# Altium schematic sheet Schem, Transadmittance MPU.SchDoc
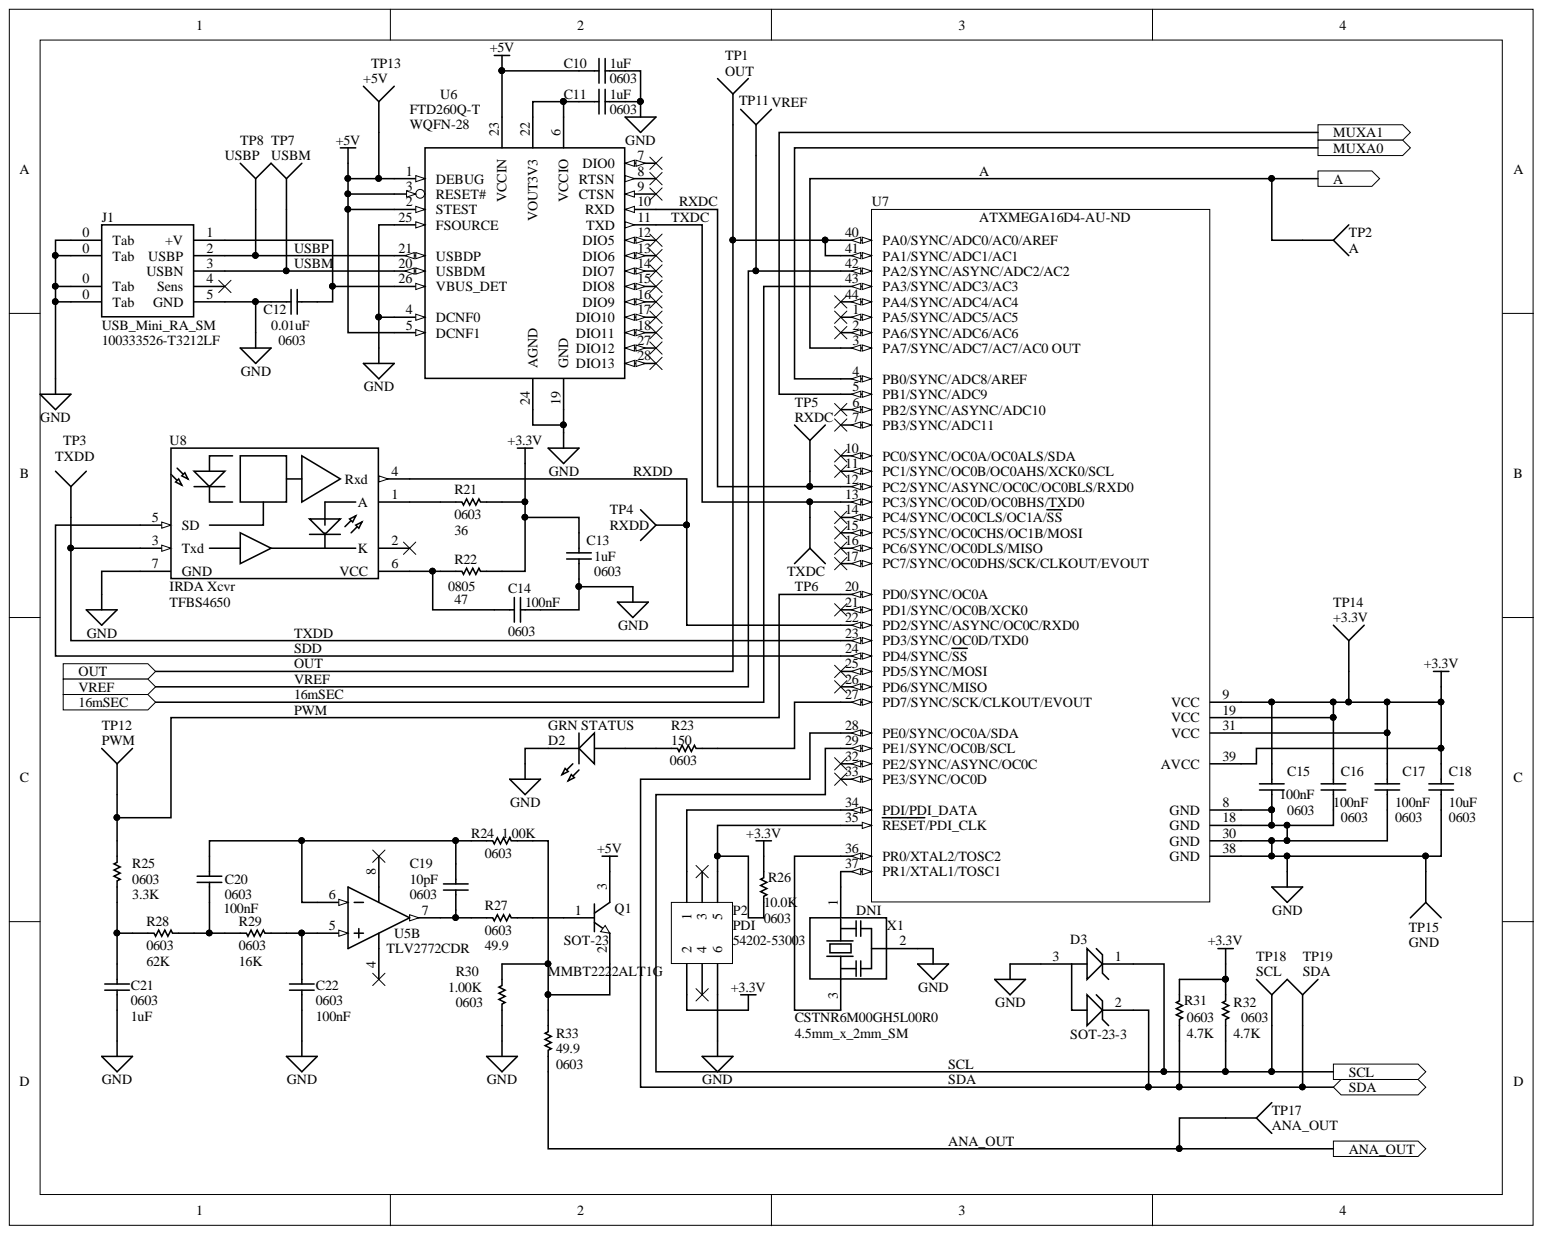
[diagram p0: Schem, Transadmittance MPU.SchDoc]

## Parts
C10  0603  value 1uF  [1608[0603]]
C11  0603  value 1uF  [1608[0603]]
C12  0603  value 0.01uF  [1608[0603]]
C13  0603  value 1uF  [1608[0603]]
C14  0603  value 100nF  [1608[0603]]
C15  0603  value 100nF  [1608[0603]]
C16  0603  value 100nF  [1608[0603]]
C17  0603  value 100nF  [1608[0603]]
C18  0603  value 10uF  [1608[0603]]
C19  0603  value 10pF  [1608[0603]]
C20  0603  value 100nF  [1608[0603]]
C21  0603  value 1uF  [1608[0603]]
C22  0603  value 100nF  [1608[0603]]
D2  GRN STATUS  [3.5X2.8X1.9]
D3  SOT-23-3  [SOT-23_L]
J1  USB_Mini_RA_SM  MFG_P/N: 100333526-T3212LF  [USB-B-Mini_SM_RA]
P2  PDI  MFG_P/N: 54202-53003  [FCI54202]
Q1  SOT-23  MFG_P/N: MMBT2222ALT1G  [SOT-23_N]
R21  0603  value 36  [RESC1608L]
R22  0805  value 47  [RESC1608L]
R23  0603  value 150  [RESC1608L]
R24  0603  value 1.00K  [RESC1608L]
R25  0603  value 3.3K  [RESC1608L]
R26  0603  value 10.0K  [RESC1608L]
R27  0603  value 49.9  [RESC1608L]
R28  0603  value 62K  [RESC1608L]
R29  0603  value 16K  [RESC1608L]
R30  0603  value 1.00K  [RESC1608L]
R31  0603  value 4.7K  [RESC1608L]
R32  0603  value 4.7K  [RESC1608L]
R33  0603  value 49.9  [RESC1608L]
TP1  OUT  [PIN1]
TP2  A  [PIN1]
TP3  TXDD  [PIN1]
TP4  RXDD  [PIN1]
TP5  RXDC  [PIN1]
TP6  TXDC  [PIN1]
TP7  USBM  [PIN1]
TP8  USBP  [PIN1]
TP11  VREF  [PIN1]
TP12  PWM  [PIN1]
TP13  +5V  [PIN1]
TP14  +3.3V  [PIN1]
TP15  GND  [PIN1]
TP17  ANA_OUT  [PIN1]
TP18  SCL  [PIN1]
TP19  SDA  [PIN1]
U5  TLV2772CDR  [D0008A_N]  (part B)
U6  WQFN-28  MFG_P/N: FTD260Q-T  [WQFN-28]
U7  Vendor P/N: ATXMEGA16D4-AU-ND  [44A_N]  (hidden comment: ATxmega16D4-AU)
U8  IRDA Xcvr  [TFBS4650]
X1  4.5mm_x_2mm_SM  value DNI  MFG_P/N: CSTNR6M00GH5L00R0  [CSTNR]

## Ports
16mSEC, A, ANA_OUT, MUXA0, MUXA1, OUT, SCL, SDA, VREF

## Nets
+3.3V: C13.1 C15.1 C16.1 C17.1 C18.1 P2.2 R21.1 R22.1 R26.2 R31.2 R32.2 TP14.1 U7.9(VCC) U7.19(VCC) U7.31(VCC) U7.39(AVCC)
+5V: C10.2 Q1.3 TP13.1 U6.1(DEBUG) U6.2(STEST) U6.3(RESET#) U6.5(DCNF1) U6.23(VCCIN)
GND: C10.1 C11.2 C12.2 C13.2 C14.2 C15.2 C16.2 C17.2 C18.2 C21.2 C22.2 D2.2 D3.3 J1.0(Tab) J1.5(GND) P2.6 R30.1 TP15.1 U6.4(DCNF0) U6.19(GND) U6.24(AGND) U6.25(FSOURCE) U7.8(GND) U7.18(GND) U7.30(GND) U7.38(GND) U8.7(GND) X1.2
16mSEC: U7.43(PA3/SYNC/ADC3/AC3) ; port 16mSEC
A: TP2.1 U7.3(PA7/SYNC/ADC7/AC7/AC0_OUT) ; port A
ANA_OUT: R33.1 TP17.1 ; port ANA_OUT
MUXA0: U7.4(PB0/SYNC/ADC8/AREF) ; port MUXA0
MUXA1: U7.5(PB1/SYNC/ADC9) ; port MUXA1
OUT: TP1.1 U7.40(PA0/SYNC/ADC0/AC0/AREF) U7.41(PA1/SYNC/ADC1/AC1) ; port OUT
PWM: R25.2 TP12.1 U7.20(PD0/SYNC/OC0A)
RXDC: TP5.1 U6.10(RXD) U7.12(PC2/SYNC/ASYNC/OC0C/OC0BLS/RXD0)
RXDD: TP4.1 U7.22(PD2/SYNC/ASYNC/OC0C/RXD0) U8.4(Rxd)
SCL: D3.1 R32.1 TP18.1 U7.29(PE1/SYNC/OC0B/SCL) ; port SCL
SDA: D3.2 R31.1 TP19.1 U7.28(PE0/SYNC/OC0A/SDA) ; port SDA
SDD: U7.24(PD4/SYNC/SS) U8.5(SD)
TXDC: TP6.1 U6.11(TXD) U7.13(PC3/SYNC/OC0D/OC0BHS/TXD0)
TXDD: TP3.1 U7.23(PD3/SYNC/OC0D/TXD0) U8.3(Txd)
USBM: J1.3(USBN) TP7.1 U6.20(USBDM)
USBP: J1.2(USBP) TP8.1 U6.21(USBDP)
VREF: TP11.1 U7.42(PA2/SYNC/ASYNC/ADC2/AC2) ; port VREF
NetC11_1: C11.1 U6.6(VCCIO) U6.22(VOUT3V3)
NetC12_1: C12.1 J1.1(+V) U6.26(VBUS_DET)
NetC14_1: C14.1 R22.2 U8.6(VCC)
NetC19_1: C19.1 C20.1 R24.2 U5.6
NetC19_2: C19.2 R27.2 U5.7
NetC20_2: C20.2 R28.1 R29.2
NetC21_1: C21.1 R25.1 R28.2
NetC22_1: C22.1 R29.1 U5.5
NetD2_1: D2.1 R23.1
NetP2_1: P2.1 U7.34(PDI/PDI_DATA)
NetP2_5: P2.5 R26.1 U7.35(RESET/PDI_CLK)
NetQ1_1: Q1.1 R27.1
NetQ1_2: Q1.2 R24.1 R30.2 R33.2
NetR21_2: R21.2 U8.1(A)
NetR23_2: R23.2 U7.27(PD7/SYNC/SCK/CLKOUT/EVOUT)
NetU7_36: U7.36(PR0/XTAL2/TOSC2) X1.3
NetU7_37: U7.37(PR1/XTAL1/TOSC1) X1.1

Not connected: J1 4(Sens); P2 3 4; U5 4 8; U6 7(DIO0) 8(RTSN) 9(CTSN) 12(DIO5) 13(DIO6) 14(DIO7) 15(DIO8) 16(DIO9) 17(DIO10) 18(DIO11) 27(DIO12) 28(DIO13); U7 1(PA5/SYNC/ADC5/AC5) 2(PA6/SYNC/ADC6/AC6) 6(PB2/SYNC/ASYNC/ADC10) 7(PB3/SYNC/ADC11) 10(PC0/SYNC/OC0A/OC0ALS/SDA) 11(PC1/SYNC/OC0B/OC0AHS/XCK0/SCL) 14(PC4/SYNC/OC0CLS/OC1A/SS) 15(PC5/SYNC/OC0CHS/OC1B/MOSI) 16(PC6/SYNC/OC0DLS/MISO) 17(PC7/SYNC/OC0DHS/SCK/CLKOUT/EVOUT) 21(PD1/SYNC/OC0B/XCK0) 25(PD5/SYNC/MOSI) 26(PD6/SYNC/MISO) 32(PE2/SYNC/ASYNC/OC0C) 33(PE3/SYNC/OC0D) 44(PA4/SYNC/ADC4/AC4); U8 2(K)

## Library data of the parts
C10 = Cap Semi: Capacitor Ceramic, SMD, 0603, .01uF, 16V, 10%; MFG: Kemet; MFG_P/N: C0603C105M3RACTU; Vendor: DigiKey; Vendor P/N: 399-7377-1-ND; Component Kind: Standard; Library Name: Miscellaneous Devices.SchLib; Signal Integrity: Cap Semi; Simulation: CAP
C11 C13 = Cap Semi: Capacitor (Semiconductor SIM Model); MFG: Kemet; MFG_P/N: C0603C105M3RACTU; Vendor: DigiKey; Vendor P/N: 399-7377-1-ND; Component Kind: Standard; Library Name: Miscellaneous Devices.SchLib; Signal Integrity: Cap Semi; Simulation: CAP
C12 = Cap Semi: Capacitor Ceramic, SMD, 0603, .01uF, 16V, 10%; MFG: Walsin; MFG_P/N: 0603B103K160CT; Vendor: DigiKey; Vendor P/N: 1292-1384-1-ND; Component Kind: Standard; Library Name: Miscellaneous Devices.SchLib; Signal Integrity: Cap Semi; Simulation: CAP
C14-C17 C20 C22 = Cap Semi: Capacitor Ceramic, SMD, 0603, 0.1uF, 16V, 10%; MFG: Samsung; MFG_P/N: CL10B104KO8NNWC; Vendor: DigiKey; Vendor P/N: 1276-1939-1-ND; Component Kind: Standard; Library Name: Miscellaneous Devices.SchLib; Signal Integrity: Cap Semi; Simulation: CAP
C18 = Cap Semi: Capacitor (Semiconductor SIM Model); MFG: AVX; MFG_P/N: 0603ZD106KAT2A; Vendor: DigiKey; Vendor P/N: 478-10766-1-ND; Component Kind: Standard; Library Name: Miscellaneous Devices.SchLib; Signal Integrity: Cap Semi; Simulation: CAP
C19 = Cap Semi: Capacitor Ceramic, SMD, 0603, 0.1uF, 16V, 10%; MFG: Samsung; MFG_P/N: C0603C100K3GACTU; Vendor: DigiKey; Vendor P/N: 399-8979-1-ND; Component Kind: Standard; Library Name: Miscellaneous Devices.SchLib; Signal Integrity: Cap Semi; Simulation: CAP
C21 = Cap Semi: Capacitor Ceramic, SMD, 0603, 0.1uF, 16V, 10%; MFG: Kemet; MFG_P/N: C0603C105M3RACTU; Vendor: DigiKey; Vendor P/N: 399-7377-1-ND; Component Kind: Standard; Library Name: Miscellaneous Devices.SchLib; Signal Integrity: Cap Semi; Simulation: CAP
D2 = LED3: Green LED, 1206; MFG: LiteOn; MFG_P/N: LTST-C150GKT; Vendor: DigiKey; Vendor P/N: 160-1169-1-ND; Component Kind: Standard; Library Name: Miscellaneous Devices.SchLib; Signal Integrity: LED; Simulation: LED3
D3 = Dual_Zener_Com_A_SOT-23: Dual Zener diode array, SOT-23-3; MFG: Diodes Inc.; MFG_P/N: AZ23C3V6-7-F; Vendor: DighiKey; Vendor P/N: AZ23C3V6-FDICT-ND; Component Kind: Standard; Library Name: Miscellaneous Devices.SchLib
J1 = USB-Mini-B-SM-RA: Recp., USB Mini B, RA SM, 3.95mm H; MFG: FCI; Vendor: DigiKey; Vendor P/N: 609-4700-1-ND; Component Kind: Standard; Library Name: Current Miscellaneous Connectors.SchLib
P2 = Header 3X2: Header, 3-Pin, Dual row, 0.1" ctrs, Vert., SM; MFG: FCI; Vendor: DigiKey; Vendor P/N: 609-6366-ND; Component Kind: Standard; Library Name: Current Miscellaneous Connectors.SchLib; Signal Integrity: Connector
Q1 = NPN: NPN Bipolar Transistor; MFG: National; Vendor: DigiKey; Vendor P/N: MMBT2222ALT1GOSCT-ND; Component Kind: Standard; Library Name: Miscellaneous Devices.SchLib; Signal Integrity: NPN; Simulation: NPN
R21 R22 R29 = Res1: Resistor; MFG: Panasonic; MFG_P/N: ERJ-3EKF1602V; Vendor: DigiKey; Vendor P/N: P16.0KHCT-ND; Component Kind: Standard; Library Name: Miscellaneous Devices.SchLib; Signal Integrity: Res; Simulation: RESISTOR
R23 = Res1: Resistor; MFG: Panasonic; MFG_P/N: ERJ-3EKF1000V; Vendor: DigiKey; Vendor P/N: P150HCT-ND; Component Kind: Standard; Library Name: Miscellaneous Devices.SchLib; Signal Integrity: Res; Simulation: RESISTOR
R24 R26 R30 = Res1: Resistor; MFG: Panasonic; MFG_P/N: ERJ-3EKF1001V; Vendor: DigiKey; Vendor P/N: P1.00KHCT-ND; Component Kind: Standard; Library Name: Miscellaneous Devices.SchLib; Signal Integrity: Res; Simulation: RESISTOR
R25 = Res1: Resistor; MFG: Panasonic; MFG_P/N: 0603ZD106KAT2A; Vendor: DigiKey; Vendor P/N: P3.30KHCT-ND; Component Kind: Standard; Library Name: Miscellaneous Devices.SchLib; Signal Integrity: Res; Simulation: RESISTOR
R27 = Res1: Resistor; MFG: Panasonic; MFG_P/N: ERJ-3EKF49R9V; Value: 49.9; Vendor: DigiKey; Vendor P/N: P49.9HCT-ND; Component Kind: Standard; Library Name: Miscellaneous Devices.SchLib; Signal Integrity: Res; Simulation: RESISTOR
R28 = Res1: Resistor; MFG: Panasonic; MFG_P/N: ERJ-3EKF6202V; Value: 62K; Vendor: DigiKey; Vendor P/N: P62.0KHCT-ND; Component Kind: Standard; Library Name: Miscellaneous Devices.SchLib; Signal Integrity: Res; Simulation: RESISTOR
R31 R32 = Res1: Resistor; MFG: Panasonic; MFG_P/N: ERJ-3EKF4701V; Vendor: DigiKey; Vendor P/N: P4.70KHCT-ND; Component Kind: Standard; Library Name: Miscellaneous Devices.SchLib; Signal Integrity: Res; Simulation: RESISTOR
R33 = Res1: Resistor; MFG: Panasonic; MFG_P/N: ERJ-3EKF49R9V; Vendor: DigiKey; Vendor P/N: P49.9HCT-ND; Component Kind: Standard; Library Name: Miscellaneous Devices.SchLib; Signal Integrity: Res; Simulation: RESISTOR
TP1-TP8 TP11-TP15 TP17-TP19 = Socket: Component Kind: Standard; Description: Socket; LatestRevisionDate: 17-Jul-2002; LatestRevisionNote: Re-released for DXP Platform.; Library Name: Current Miscellaneous Connectors.SchLib; Signal Integrity: Connector; Publisher: Altium Limited
U5 = CMP-0272-03014-3: Dual High-Slew-Rate Rail-to-Rail Output Operational Amplifier, 2.5 to 6 V, 0 to 70 degC, 8-pin SOIC (D8), Green (RoHS & no Sb/Br); MFG: TI; Vendor: DigiKey; Vendor P/N: 296-10677-1-ND; Component Kind: Standard; ComponentLink1Description: Manufacturer URL; ComponentLink1URL: http://www.ti.com/; ComponentLink2Description: Datasheet; ComponentLink2URL: http://www.ti.com/lit/ds/symlink/tlv2772.pdf; ComponentLink3Description: Package Specification; ComponentLink3URL: http://www.ti.com/litv/pdf/msoi002j; Library Name: Altium Content Vault; MFG_P/N: TLV2772CDR
U6 = FT260Q-T: USB/serial Converter; MFG: FTDI; Vendor: DigiKey; Vendor P/N: 768-1343-1-ND; Component Kind: Standard; Library Name: FTDI Communication USB.IntLib
U7 = ATxmega16D4-AU: 8/16-bit AVR XMEGA D4 Microcontroller, 1.6-3.6V, 16KB + 4KB Flash, 1KB EEPROM, 2KB SRAM, 44-pin TQFP, Industrial Grade (-40°C to 85°C), Pb-Free; MFG: Microchip; Vendor: Digi-Key; Component Kind: Standard; ComponentLink1Description: Manufacturer Link; ComponentLink1URL: http://www.atmel.com/products/avr/default.asp?; ComponentLink2Description: ATxmega128D4/64D4/32D4/16D4 Datasheet; ComponentLink2URL: http://www.atmel.com/dyn/resources/prod_documents/doc8135.pdf; ComponentLink3Description: Atmel.com Home; ComponentLink3URL: http://www.atmel.com; Library Name: Atmel Microcontroller 8-16-Bit AVR XMEGA.IntLib; MFG_P/N: ATXmega16D4-AU
U8 = TFBS4650: IR optical transceiver, SM, RA; MFG: Vishay; Vendor: DigiKey; Vendor P/N: 751-1063-1-ND; Component Kind: Standard; Library Name: Vishay Communication Transceiver.SchLib; MFG_P/N: TFBS4650
X1 = CSTNR_GH5C: Resonator, Cer., int. caps, 4.5mm x 2 mm x 1.1mm; MFG: Murata; Vendor: Mouser; Vendor P/N: 81-CSTNR6M00GH5L00R; Component Kind: Standard; Library Name: Miscellaneous Devices.SchLib
